# Altium schematic sheet 03_ EFR32 Signal Assignments.SchDoc
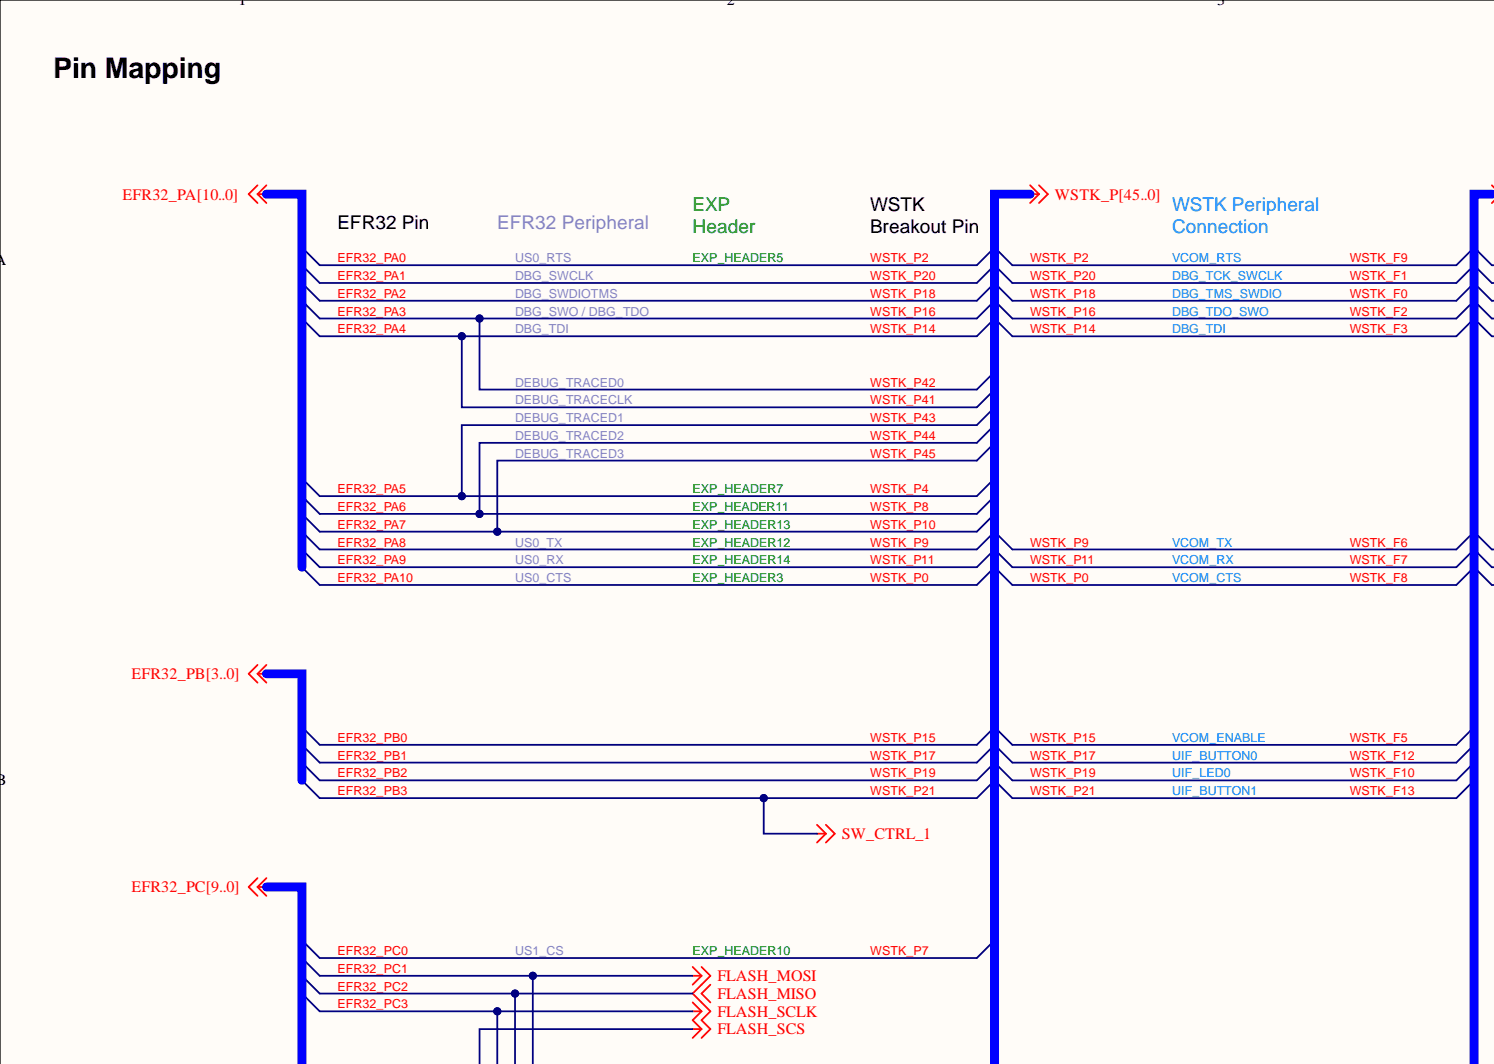
[diagram p0: 03_ EFR32 Signal Assignments.SchDoc, top left]
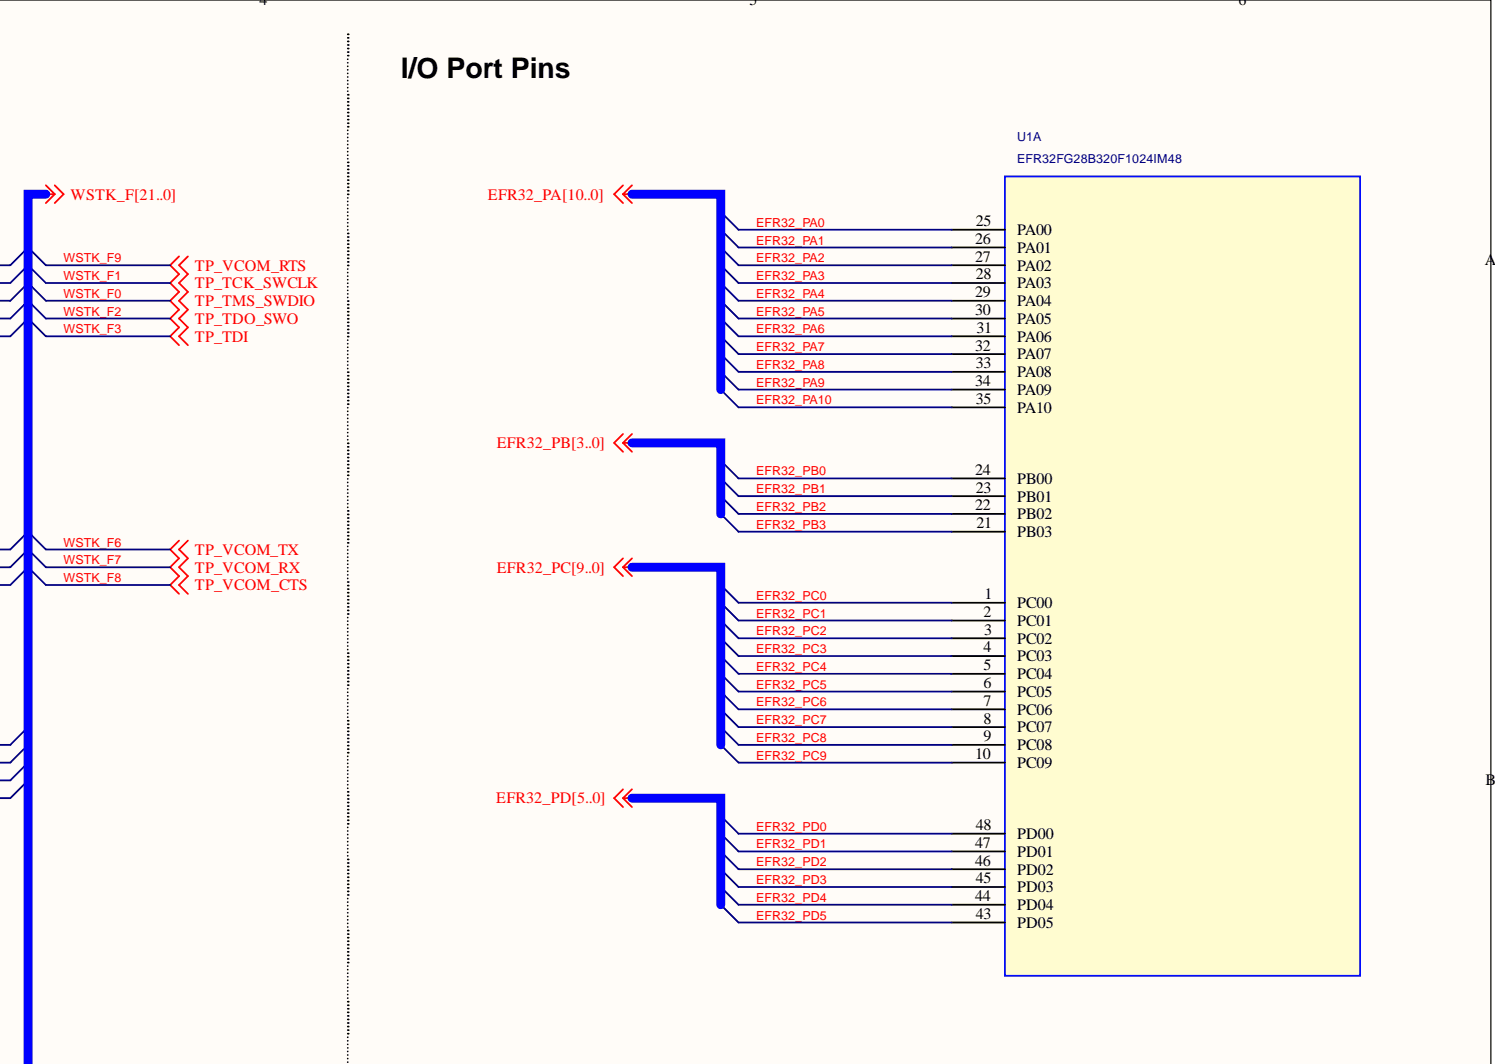
[diagram p1: 03_ EFR32 Signal Assignments.SchDoc, top right]
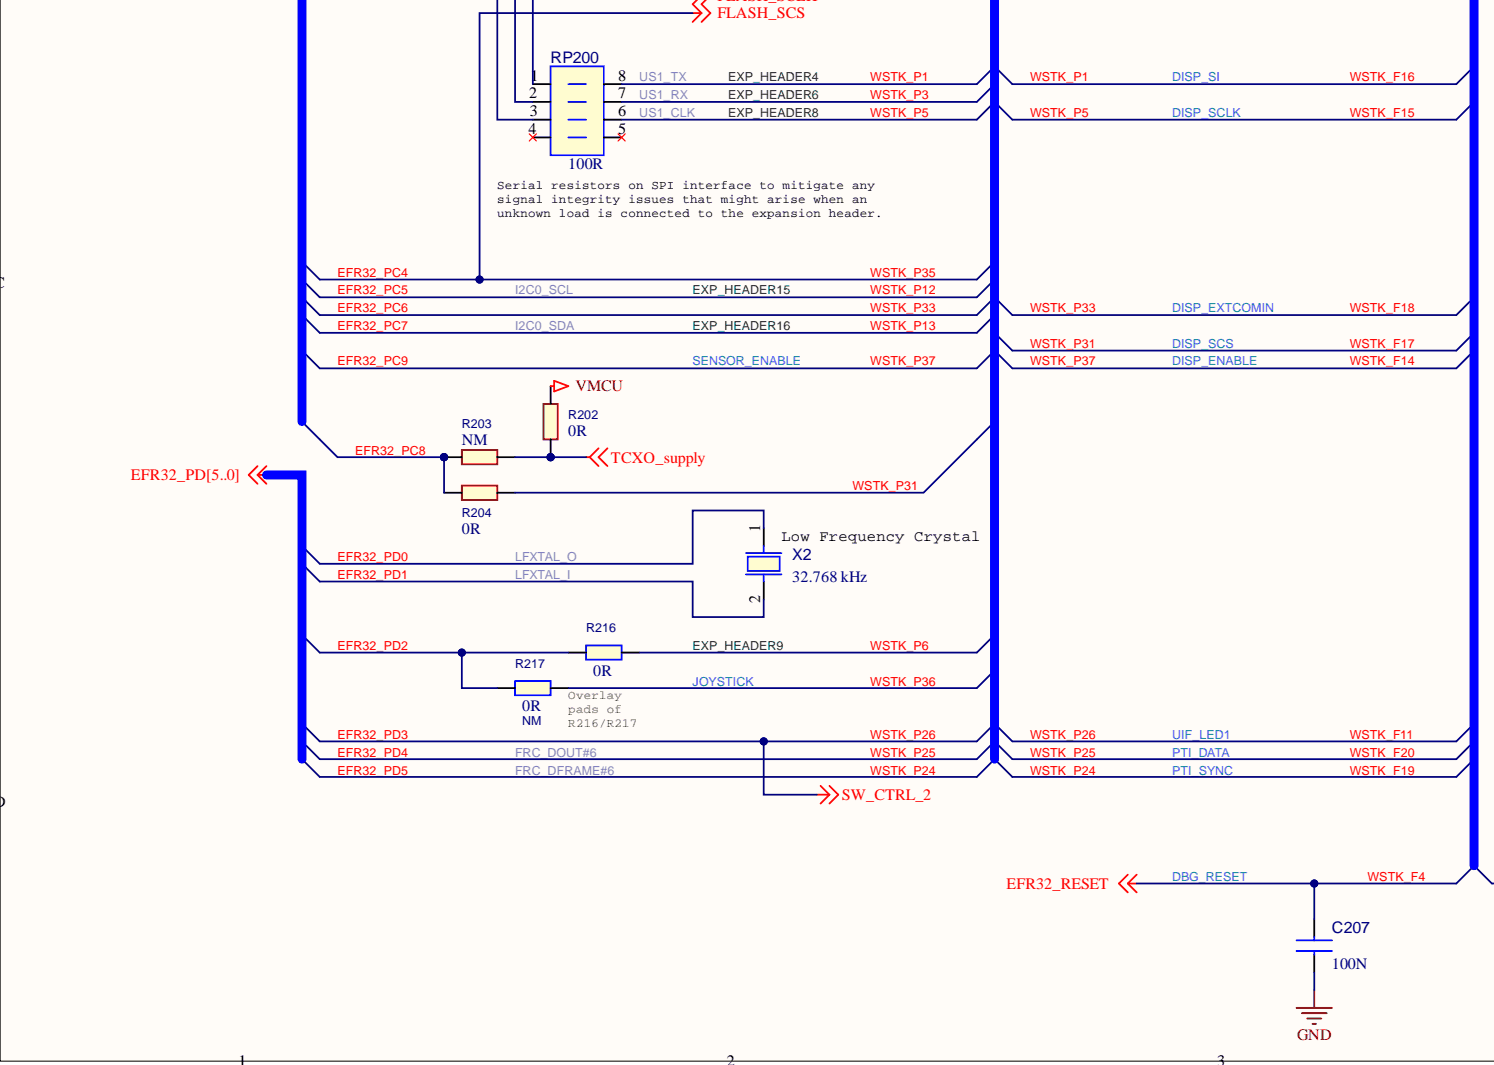
[diagram p2: 03_ EFR32 Signal Assignments.SchDoc, bottom left]
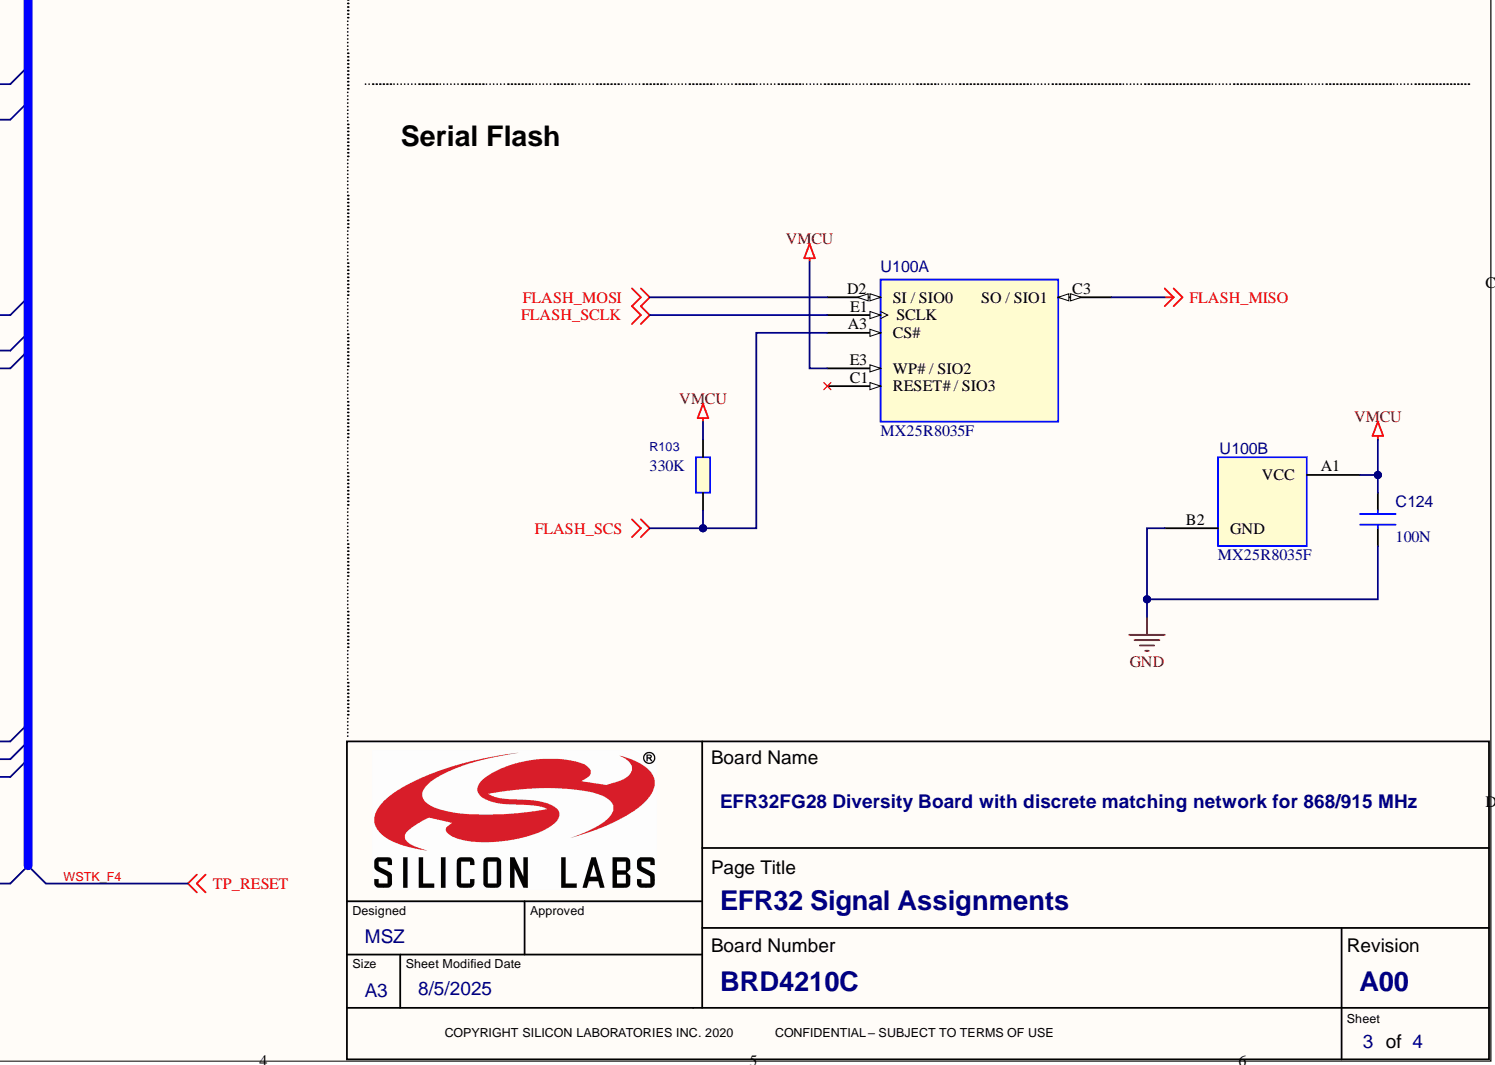
[diagram p3: 03_ EFR32 Signal Assignments.SchDoc, bottom right]

## Parts
C124  100N  Part Reference: C124  [C0201_L]
C207  100N  Part Reference: C207  [C0201_L]
R103  330K  Part Reference: R103  [R0201_L]
R202  0R  [R0201_L]
R203  NM  [R0201_L]
R204  0R  [R0201_L]
R216  0R  Part Reference: R216  [R0402_L]
R217  0R  Not Mounted: NM  Part Reference: R217  [R0402_L]
RP200  100R  Part Reference: RP200  [RES_MNR04-8]
U1  EFR32FG28B320F1024IM48  [QFN48_M040_6.0X6.0_EP_SL]  (part A)
U100  MX25R8035F  [BGA8_M040_1.24x1.75_WLCSP]  (parts A,B)
X2  32.768 kHz  Part Reference: X2  [X_MCS_CM8V-T1A]

## Nets
GND: C124.2 C207.2 U100.B2(GND)
TCXO_supply: R202.1 R203.1
VMCU: C124.1 R103.2 R202.2 U100.A1(VCC) U100.E3(WP#_/_SIO2)
DBG_RESET: C207.1  [also labelled ]
DBG_SWCLK: U1.26(PA01)  [also labelled ]
DBG_SWCLK: U1.26(PA01)  [also labelled ]
DBG_SWCLK: U1.26(PA01)  [also labelled ]
DBG_SWDIOTMS: U1.27(PA02)  [also labelled ]
DBG_SWDIOTMS: U1.27(PA02)  [also labelled ]
DBG_SWDIOTMS: U1.27(PA02)  [also labelled ]
DBG_SWO / DBG_TDO: U1.28(PA03)  [also labelled ]
DBG_SWO / DBG_TDO: U1.28(PA03)  [also labelled ]
DBG_SWO / DBG_TDO: U1.28(PA03)  [also labelled ]
DBG_TDI: U1.29(PA04)  [also labelled ]
DBG_TDI: U1.29(PA04)  [also labelled ]
DBG_TDI: U1.29(PA04)  [also labelled ]
DEBUG_TRACED1: U1.30(PA05)  [also labelled ]
DEBUG_TRACED1: U1.30(PA05)  [also labelled ]
DEBUG_TRACED2: U1.31(PA06)  [also labelled ]
DEBUG_TRACED2: U1.31(PA06)  [also labelled ]
DEBUG_TRACED3: U1.32(PA07)  [also labelled ]
DEBUG_TRACED3: U1.32(PA07)  [also labelled ]
DISP_ENABLE: U1.10(PC09)  [also labelled ]
DISP_ENABLE: U1.10(PC09)  [also labelled ]
DISP_ENABLE: U1.10(PC09)  [also labelled ]
DISP_EXTCOMIN: U1.7(PC06)  [also labelled ]
DISP_EXTCOMIN: U1.7(PC06)  [also labelled ]
DISP_EXTCOMIN: U1.7(PC06)  [also labelled ]
DISP_SCLK: RP200.6  [also labelled ]
DISP_SCLK: RP200.6  [also labelled ]
DISP_SCS: R204.1  [also labelled ]
DISP_SCS: R204.1  [also labelled ]
DISP_SI: RP200.8  [also labelled ]
DISP_SI: RP200.8  [also labelled ]
EFR32_PA0: U1.25(PA00)  [also labelled ]
EFR32_PA0: U1.25(PA00)  [also labelled ]
EFR32_PA0: U1.25(PA00)  [also labelled ]
EFR32_PA8: U1.33(PA08)  [also labelled ]
EFR32_PA8: U1.33(PA08)  [also labelled ]
EFR32_PA8: U1.33(PA08)  [also labelled ]
EFR32_PA9: U1.34(PA09)  [also labelled ]
EFR32_PA9: U1.34(PA09)  [also labelled ]
EFR32_PA9: U1.34(PA09)  [also labelled ]
EFR32_PA10: U1.35(PA10)  [also labelled ]
EFR32_PA10: U1.35(PA10)  [also labelled ]
EFR32_PA10: U1.35(PA10)  [also labelled ]
EFR32_PB0: U1.24(PB00)  [also labelled ]
EFR32_PB0: U1.24(PB00)  [also labelled ]
EFR32_PB0: U1.24(PB00)  [also labelled ]
EFR32_PB1: U1.23(PB01)  [also labelled ]
EFR32_PB1: U1.23(PB01)  [also labelled ]
EFR32_PB1: U1.23(PB01)  [also labelled ]
EFR32_PB2: U1.22(PB02)  [also labelled ]
EFR32_PB2: U1.22(PB02)  [also labelled ]
EFR32_PB2: U1.22(PB02)  [also labelled ]
EFR32_PB3: U1.21(PB03)  [also labelled ]
EFR32_PB3: U1.21(PB03)  [also labelled ]
EFR32_PB3: U1.21(PB03)  [also labelled ]
EFR32_PC0: U1.1(PC00)  [also labelled ]
EFR32_PC0: U1.1(PC00)  [also labelled ]
EFR32_PC1: RP200.1 U1.2(PC01) U100.D2(SI_/_SIO0)  [also labelled ]
EFR32_PC2: RP200.2 U1.3(PC02) U100.C3(SO_/_SIO1)  [also labelled ]
EFR32_PC3: RP200.3 U1.4(PC03) U100.E1(SCLK)  [also labelled ]
EFR32_PC4: R103.1 U1.5(PC04) U100.A3(CS#)  [also labelled ]
EFR32_PC4: R103.1 U1.5(PC04) U100.A3(CS#)  [also labelled ]
EFR32_PC5: U1.6(PC05)  [also labelled ]
EFR32_PC5: U1.6(PC05)  [also labelled ]
EFR32_PC7: U1.8(PC07)  [also labelled ]
EFR32_PC7: U1.8(PC07)  [also labelled ]
EFR32_PC8: R203.2 R204.2 U1.9(PC08)  [also labelled ]
EFR32_PD0: U1.48(PD00) X2.1  [also labelled ]
EFR32_PD1: U1.47(PD01) X2.2  [also labelled ]
EFR32_PD2: R216.1 R217.1 U1.46(PD02)  [also labelled ]
EFR32_PD3: U1.45(PD03)  [also labelled ]
EFR32_PD3: U1.45(PD03)  [also labelled ]
EFR32_PD3: U1.45(PD03)  [also labelled ]
EFR32_PD4: U1.44(PD04)  [also labelled ]
EFR32_PD4: U1.44(PD04)  [also labelled ]
EFR32_PD4: U1.44(PD04)  [also labelled ]
EFR32_PD5: U1.43(PD05)  [also labelled ]
EFR32_PD5: U1.43(PD05)  [also labelled ]
EFR32_PD5: U1.43(PD05)  [also labelled ]
EXP_HEADER6: RP200.7  [also labelled ]
EXP_HEADER9: R216.2  [also labelled ]
JOYSTICK: R217.2  [also labelled ]
WSTK_F21:
WSTK_P22:
WSTK_P23:
WSTK_P27:
WSTK_P28:
WSTK_P29:
WSTK_P30:
WSTK_P32:
WSTK_P34:
WSTK_P38:
WSTK_P39:
WSTK_P40:

Not connected: RP200 4 5; U100 C1(RESET#_/_SIO3)

## Library data of the parts
C124 C207 = C0201: Rating: 16V; Tolerance: 20%; Component Kind: Standard; PCB Footprint: C0201_L; Technology: X7S; Value: 100N
R103 R202-R204 = R0201: Component Kind: Standard; Price_1K: 0.005
  R103: PCB Footprint: R0201_L; Value: 330K
  R202 R204: Library Name: BRD4210A_Library.SchLib; Value: 0R
  R203: Library Name: BRD4210A_Library.SchLib; Value: NM
R216 R217 = R0402: Component Kind: Standard; PCB Footprint: R0402_L; Price_1K: 0.005; Value: 0R
RP200 = MNR04M0APJ101: Mfg: ROHM; Component Kind: Standard; Mfg #: MNR04M0APJ101; PCB Footprint: RES_MNR04-8; Price_1K: 0.0122; Value: 100R
U1 = EFR32xG23_QFN48: Part Reference: U1; MFG: Silicon Labs; MFG #: EFR32ZG23B020F512IM48-CR; Component Kind: Standard; PCB Footprint: QFN48_M040_6.0X6.0_EP_SL; Value: EFR32FG28B320F1024IM48
U100 = MX25R8035: Part Reference: U100; Mfg: Macronix; Mfg #: MX25R8035FBDIL0; Component Kind: Standard; PCB Footprint: BGA8_M040_1.24x1.75_WLCSP; Price_1K: 0.25; Value: MX25R8035F
X2 = CM8V-T1A: Mfg: Micro Crystal AG; Mfg #: CM8V-T1A-32.768KHZ-7PF-20PPM-TA-QC; Component Kind: Standard; PCB Footprint: X_MCS_CM8V-T1A; Price_1K: 0.3; Value: 32.768 kHz
